# Altium schematic sheet UVLO_MODULE_TOP.SchDoc(TOP)
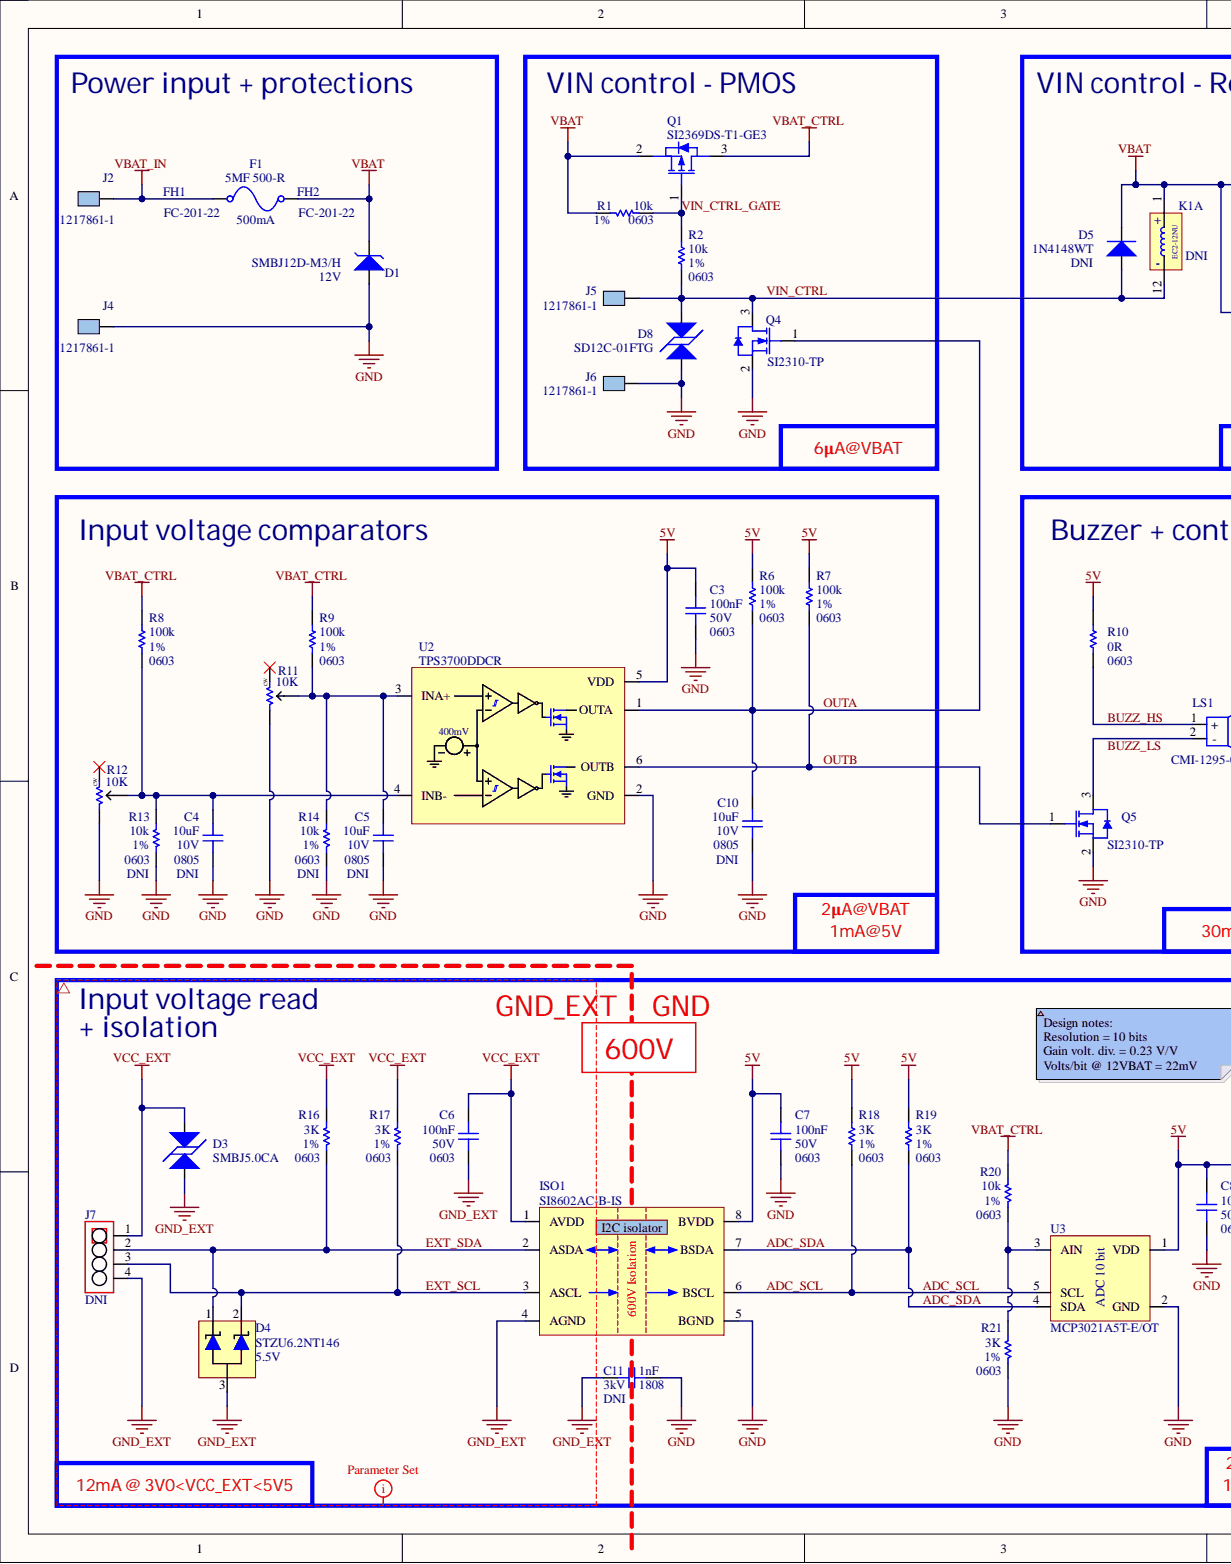
[diagram p0: UVLO_MODULE_TOP.SchDoc, left]
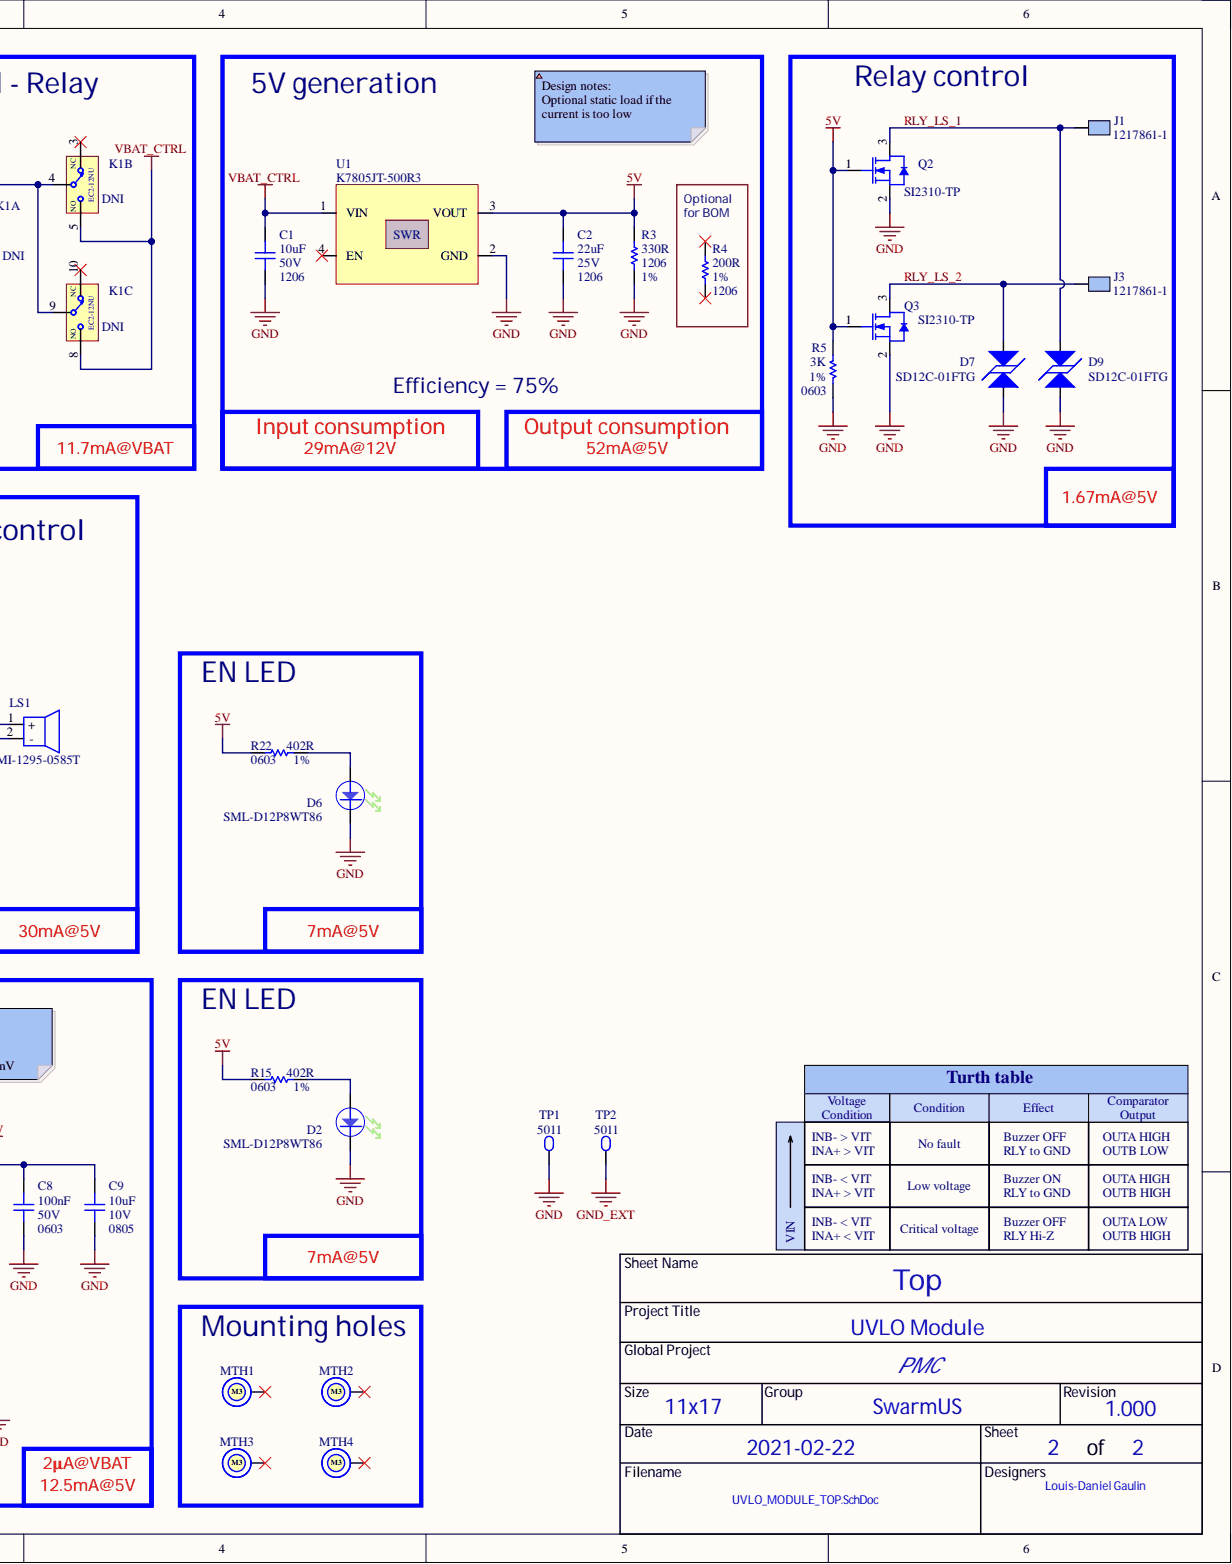
[diagram p1: UVLO_MODULE_TOP.SchDoc, right]

## Parts
C1  value 10uF  Package: 1206  Voltage Rating: 50V  [CAP_CER_1206]  (hidden comment: GRT31CR61H106KE01L)
C2  value 22uF  Package: 1206  Voltage Rating: 25V  [CAP_CER_1206]  (hidden comment: CL31A226KAHNNNE)
C3  value 100nF  Package: 0603  Voltage Rating: 50V  [CAP_CER_0603]  (hidden comment: CC0603KRX7R9BB104)
C4  value 10uF  Assembly Note: DNI  Package: 0805  Voltage Rating: 10V  [CAP_CER_0805]  (hidden comment: LMK212BJ106KG-T)
C5  value 10uF  Assembly Note: DNI  Package: 0805  Voltage Rating: 10V  [CAP_CER_0805]  (hidden comment: LMK212BJ106KG-T)
C6  value 100nF  Package: 0603  Voltage Rating: 50V  [CAP_CER_0603]  (hidden comment: CC0603KRX7R9BB104)
C7  value 100nF  Package: 0603  Voltage Rating: 50V  [CAP_CER_0603]  (hidden comment: CC0603KRX7R9BB104)
C8  value 100nF  Package: 0603  Voltage Rating: 50V  [CAP_CER_0603]  (hidden comment: CC0603KRX7R9BB104)
C9  value 10uF  Package: 0805  Voltage Rating: 10V  [CAP_CER_0805]  (hidden comment: LMK212BJ106KG-T)
C10  value 10uF  Assembly Note: DNI  Package: 0805  Voltage Rating: 10V  [CAP_CER_0805]  (hidden comment: LMK212BJ106KG-T)
C11  value 1nF  Assembly Note: DNI  Package: 1808  Voltage Rating: 3kV  [CAP_CER_1808]  (hidden comment: 1808HC102KAT1A)
D1  SMBJ12D-M3/H  value 12V  [TVS_SMBJ12D-M3/H]
D2  SML-D12P8WT86  [LED_SML-D12P8WT86]
D3  SMBJ5.0CA  [TVS_PWR_SMBJ5.0CA]
D4  STZU6.2NT146  Voltage Rating: 5.5V  [TVS_DATA_STZU6.2NT146]
D5  1N4148WT  Assembly Note: DNI  [DIO_1N4148WT]
D6  SML-D12P8WT86  [LED_SML-D12P8WT86]
D7  SD12C-01FTG  [TVS_DATA_SD12C-01FTG]
D8  SD12C-01FTG  [TVS_DATA_SD12C-01FTG]
D9  SD12C-01FTG  [TVS_DATA_SD12C-01FTG]
F1  5MF 500-R  Current Rating: 500mA  [FUS_5MF 500-R]
FH1  FC-201-22  [FHO_FC-201-22]
FH2  FC-201-22  [FHO_FC-201-22]
ISO1  SI8602AC-B-IS  value 600V Isolation  [ISO_SI8602AC-B-IS]
J1  1217861-1  [CON_1217861-1]
J2  1217861-1  [CON_1217861-1]
J3  1217861-1  [CON_1217861-1]
J4  1217861-1  [CON_1217861-1]
J5  1217861-1  [CON_1217861-1]
J6  1217861-1  [CON_1217861-1]
J7  Assembly Note: DNI  [CON_HDR_1X4]  (hidden comment: CON_HDR_1X4)
K1  EC2-12NU  Assembly Note: DNI  [RLY_EC2-12NU]  (parts A,B,C)
LS1  CMI-1295-0585T  [UND_SPK_CMI-1295-0585T]
MTH1  M3  [MTH_M3]  (no BOM)
MTH2  M3  [MTH_M3]  (no BOM)
MTH3  M3  [MTH_M3]  (no BOM)
MTH4  M3  [MTH_M3]  (no BOM)
Q1  SI2369DS-T1-GE3  [TRX_PMOS_SI2369DS-T1-GE3]
Q2  SI2310-TP  [TRX_NMOS_SI2310-TP]
Q3  SI2310-TP  [TRX_NMOS_SI2310-TP]
Q4  SI2310-TP  [TRX_NMOS_SI2310-TP]
Q5  SI2310-TP  [TRX_NMOS_SI2310-TP]
R1  value 10k  Package: 0603  Tolerance: 1%  [RES_0603]  (hidden comment: RC0603FR-0710KL)
R2  value 10k  Package: 0603  Tolerance: 1%  [RES_0603]  (hidden comment: RC0603FR-0710KL)
R3  value 330R  Package: 1206  Tolerance: 1%  [RES_1206]  (hidden comment: RC1206FR-07330RL)
R4  value 200R  Package: 1206  Tolerance: 1%  [RES_1206]  (hidden comment: CRCW1206200RFKEAC)  (mechanical)
R5  value 3K  Package: 0603  Tolerance: 1%  [RES_0603]  (hidden comment: RC0603FR-073KL)
R6  value 100k  Package: 0603  Tolerance: 1%  [RES_0603]  (hidden comment: RC0603FR-07100KL)
R7  value 100k  Package: 0603  Tolerance: 1%  [RES_0603]  (hidden comment: RC0603FR-07100KL)
R8  value 100k  Package: 0603  Tolerance: 1%  [RES_0603]  (hidden comment: RC0603FR-07100KL)
R9  value 100k  Package: 0603  Tolerance: 1%  [RES_0603]  (hidden comment: RC0603FR-07100KL)
R10  value 0R  Package: 0603  [RES_0603]  (hidden comment: RC0603JR-070RL)
R11  value 10K  [POT_3362P-1-103LF]  (hidden comment: 3362P-1-103LF)
R12  value 10K  [POT_3362P-1-103LF]  (hidden comment: 3362P-1-103LF)
R13  value 10k  Assembly Note: DNI  Package: 0603  Tolerance: 1%  [RES_0603]  (hidden comment: RC0603FR-0710KL)
R14  value 10k  Assembly Note: DNI  Package: 0603  Tolerance: 1%  [RES_0603]  (hidden comment: RC0603FR-0710KL)
R15  value 402R  Package: 0603  Tolerance: 1%  [RES_0603]  (hidden comment: RC0603FR-07402RL)
R16  value 3K  Package: 0603  Tolerance: 1%  [RES_0603]  (hidden comment: RC0603FR-073KL)
R17  value 3K  Package: 0603  Tolerance: 1%  [RES_0603]  (hidden comment: RC0603FR-073KL)
R18  value 3K  Package: 0603  Tolerance: 1%  [RES_0603]  (hidden comment: RC0603FR-073KL)
R19  value 3K  Package: 0603  Tolerance: 1%  [RES_0603]  (hidden comment: RC0603FR-073KL)
R20  value 10k  Package: 0603  Tolerance: 1%  [RES_0603]  (hidden comment: RC0603FR-0710KL)
R21  value 3K  Package: 0603  Tolerance: 1%  [RES_0603]  (hidden comment: RC0603FR-073KL)
R22  value 402R  Package: 0603  Tolerance: 1%  [RES_0603]  (hidden comment: RC0603FR-07402RL)
TP1  5011  [TPO_5011]
TP2  5011  [TPO_5011]
U1  K7805JT-500R3  [REG_SWR_K7805JT-500R3]
U2  TPS3700DDCR  [CMP_TPS3700DDCR]
U3  MCP3021A5T-E/OT  [ADC_MCP3021A5T-E/OT]

## Nets
5V: C2.2 C3.2 C7.2 C8.2 C9.2 ISO1.8(BVDD) Q2.1 Q3.1 R3.2 R5.2 R6.2 R7.2 R10.2 R15.1 R18.2 R19.2 R22.1 U1.3(VOUT) U2.5(VDD) U3.1(VDD)
GND: C1.1 C2.1 C3.1 C4.1 C5.1 C7.1 C8.1 C9.1 C10.1 C11.1 D1.1 D2.K D6.K D7.2 D8.2 D9.2 ISO1.5(BGND) J4.1 J6.1 Q2.2 Q3.2 Q4.2 Q5.2 R3.1 R5.1 R11.1 R12.1 R13.1 R14.1 R21.1 TP1.1 U1.2(GND) U2.2(GND) U3.2(GND)
GND_EXT: C6.1 C11.2 D3.2 D4.3 ISO1.4(AGND) J7.4 TP2.1
VBAT: D1.2 D5.K FH2.1 K1.1 K1.4 K1.9 Q1.2 R1.2
VBAT_CTRL: C1.2 K1.5 K1.8 Q1.3 R8.2 R9.2 R20.2 U1.1(VIN)
VBAT_IN: FH1.1 J2.1
VCC_EXT: C6.2 D3.1 ISO1.1(AVDD) J7.1 R16.2 R17.2
ADC_SCL: ISO1.6(BSCL) R18.1 U3.5(SCL)
ADC_SDA: ISO1.7(BSDA) R19.1 U3.4(SDA)
BUZZ_HS: LS1.1 R10.1
BUZZ_LS: LS1.2 Q5.3
EXT_SCL: D4.2 ISO1.3(ASCL) J7.3 R17.1
EXT_SDA: D4.1 ISO1.2(ASDA) J7.2 R16.1
OUTA: C10.2 Q4.1 R6.1 U2.1(OUTA)
OUTB: Q5.1 R7.1 U2.6(OUTB)
RLY_LS_1: D9.1 J1.1 Q2.3
RLY_LS_2: D7.1 J3.1 Q3.3
VIN_CTRL: D5.A D8.1 J5.1 K1.12 Q4.3 R2.1
VIN_CTRL_GATE: Q1.1 R1.1 R2.2
NetC4_2: C4.2 R8.1 R12.2 R13.2 U2.4(INB-)
NetC5_2: C5.2 R9.1 R11.2 R14.2 U2.3(INA+)
NetD2_A: D2.A R15.2
NetD6_A: D6.A R22.2
NetR20_1: R20.1 R21.2 U3.3(AIN)

Not connected: K1 3 10; MTH1 1; MTH2 1; MTH3 1; MTH4 1; R4 1 2; R11 3; R12 3; U1 4(EN)

## Library data of the parts
C1 = CAP_CER_GRT31CR61H106KE01L: CAP - CER 10UF 10% 50V X5R - 1206; Manufacturer #1: Murata Electronics North America; Cryo: UNKNOWN; GRAMS Lib Spec: 2.8; Polarized: No; Assembly Type: SMD; Component Kind: Standard; HelpURL: https://search.murata.co.jp/Ceramy/image/img/A01X/G101/ENG/GRT31CR61H106KE01-01.pdf; Library Name: GRAMS_MASTER_LIB.IntLib; Magnetic Material: Unknown; Part Number #1: GRT31CR61H106KE01L; Supplier 1: Digi-Key; Supplier Part Number 1: 490-12456-1-ND; Temperature: -55°C ~ 85°C; Tolerance: 10%; CreatedBy/Date: Nicolas Roy - 2018/12/19; VerifiedBy: Nicolas V. (2019-01-22)
C2 = CAP_CER_CL31A226KAHNNNE: CAP - CER 22uF 10% 25V X5R - 1206; Manufacturer #1: Samsung Electro-Mechanics; Cryo: UNKNOWN; GRAMS Lib Spec: 2.8; Polarized: NO; Assembly Type: SMD; Component Kind: Standard; HelpURL: http://www.samsungsem.com/global/support/product-search/mlcc/1207445_4880.html; Library Name: GRAMS_MASTER_LIB.IntLib; Magnetic Material: Unknown; Part Number #1: CL31A226KAHNNNE; Part Number #2: 1276-3047-1-ND; Supplier 1: Digi-Key; Supplier Part Number 1: 1276-3047-1-ND; Temperature: -55°C ~ 85°C; Tolerance: 10%; CreatedBy/Date: Philippe Arsenault/21 Janvier 2020; VerifiedBy: C.Paulin - 28/01/2020
C3 C6-C8 = CAP_CER_CC0603KRX7R9BB104: CAP - CER 100nF 50V X7R - 0603; Manufacturer #1: Yageo; Cryo: UNKNOWN; GRAMS Lib Spec: 2.8; Polarized: NO; Tolerance: 10%; Assembly Type: SMD; Component Kind: Standard; HelpURL: https://www.yageo.com/upload/media/product/productsearch/datasheet/mlcc/UPY-GPHC_X7R_6.3V-to-50V_18.pdf; Magnetic Material: UNKNOWN; Part Number #1: CC0603KRX7R9BB104; Supplier 1: Digi-Key; Supplier Part Number 1: 311-1344-1-ND; Temperature: -55°C ~ 125°C; CreatedBy/Date: Louis-Daniel Gaulin / 25-02-2021; Library Name: SWARMUS_LIB.IntLib; VerifiedBy: Hubert Dube - 26/02/2021
C4 C5 C9 C10 = CAP_CER_LMK212BJ106KG-T: CAP - CER 10UF 10% 10V X5R - 0805; Manufacturer #1: Taiyo Yuden; Cryo: UNKNOWN; GRAMS Lib Spec: 2.8; Polarized: NO; Assembly Type: SMD; Component Kind: Standard; HelpURL: https://www.yuden.co.jp/productdata/catalog/mlcc_all_e.pdf; Library Name: GRAMS_MASTER_LIB.IntLib; Magnetic Material: Unknown; Part Number #1: LMK212BJ106KG-T; Supplier 1: Digi-Key; Supplier Part Number 1: 587-1300-1-ND; Temperature: -55°C ~ 85°C; Tolerance: 10%; CreatedBy/Date: Caroline Paulin / 25 juillet 2018; VerifiedBy: Nicolas V. (2019-01-22)
C11 = CAP_CER_1808HC102KAT1A: CAP - CER 1nF 10% 3kV X7R - 1808; Manufacturer #1: AVX Corporation; Cryo: UNKNOWN; GRAMS Lib Spec: 2.8; Polarized: No; Assembly Type: SMD; Component Kind: Standard; HelpURL: http://datasheets.avx.com/AVX-HV_MLCC.pdf; Library Name: GRAMS_MASTER_LIB.IntLib; Magnetic Material: Unknown; Part Number #1: 1808HC102KAT1A; Supplier 1: Digi-Key; Supplier Part Number 1: 478-2981-1-ND; Temperature: -55°C ~ 125°C; Tolerance: 10%; CreatedBy/Date: Joel Lemay 2018-08-29; VerifiedBy: Caroline Paulin - 7 sept 2018
D1 = TVS_SMBJ12D-M3/H: TVS - DIODE 12V 19.6V - DO-214AA; Manufacturer #1: Vishay Semiconductor Diodes Division; Cryo: UNKNOWN; Current Rating: 30.6A peak pulse; GRAMS Lib Spec: 2.8c; Polarized: Yes; Power Max: 600W peak pulse; Voltage Rating: Vbr = 13.5, Vc = 19.6; Assembly Type: SMD; Component Kind: Standard; HelpURL: http://www.vishay.com/docs/87606/87606.pdf; Library Name: GRAMS_MASTER_LIB.IntLib; Magnetic Material: Unknown; Package: DO-214AA; Part Number #1: SMBJ12D-M3/H; Supplier 1: Digi-Key; Supplier Part Number 1: SMBJ12D-M3/HGICT-ND; Temperature: -55°C ~ 150°C; CreatedBy/Date: Joel Lemay 2019-04-17; VerifiedBy: C.Paulin 6/02/2020
D2 D6 = LED_SML-D12P8WT86: LED - GREEN DIFFUSED 0603 SMD - 0603; Manufacturer #1: Rohm Semiconductor; Cryo: UNKNOWN; Current Rating: 1-20mA; GRAMS Lib Spec: 2.8; Note: Operate at 2mA; Polarized: Yes; Value: 560 nm (green); Voltage rating: 2.2V (Forward), 5V (max Reverse); Assembly Type: SMD; Component Kind: Standard; HelpURL: https://d1d2qsbl8m0m72.cloudfront.net/en/products/databook/datasheet/opto/led/chip_mono/sml-d12x8_d13x8-e.pdf; Library Name: GRAMS_MASTER_LIB.IntLib; Magnetic Material: Unknown; Package: 0603; Part Number #1: SML-D12P8WT86; Supplier 1: Digi-Key; Supplier Part Number 1: 511-1579-2-ND; Temperature: -40 ~ 85 °C; CreatedBy/Date: Keven Deslandes - 2018/11/21; VerifiedBy: Caroline Paulin - 13 février 2019
D3 = TVS_PWR_SMBJ5.0CA: TVS - BIDIR 5V 9.2V 600W - DO214AA; Manufacturer #1: Littelfuse Inc.; Cryo: UNKNOWN; Current Rating: 65.3 A (Ipp); GRAMS Lib Spec: 2.8; Polarized: NO; Power Max: 600W (Pppm); Value: Vbr = 6.4V -  Clamp = 9.2V; Voltage Rating: 5V Standoff; Assembly Type: SMD; Component Kind: Standard; HelpURL: http://www.littelfuse.com/~/media/electronics/datasheets/tvs_diodes/littelfuse_tvs_diode_smbj_datasheet.pdf.pdf; Library Name: GRAMS_MASTER_LIB.IntLib; Magnetic Material: UNKNOWN; Package: DO214AA; Part Number #1: SMBJ5.0CA; Supplier 1: Digi-Key; Supplier Part Number 1: SMBJ5.0CALFCT-ND; Temperature: -65°C ~ 150°C (TJ); CreatedBy/Date: Jonathan Bouchard - 2019-03-22; VerifiedBy: Nicolas V. (2019-05-06)
D4 = TVS_DATA_STZU6.2NT146: TVS - TVS DIODE 5.5V 5.9V - SOT-23-3; Manufacturer #1: Rohm Semiconductor; Cryo: UNKNOWN; GRAMS Lib Spec: 2.8; Polarized: No; Power Max: 0.2W; Assembly Type: SMD; Component Kind: Standard; HelpURL: https://d1d2qsbl8m0m72.cloudfront.net/en/products/databook/datasheet/discrete/diode/zener/stzu6.2nt146-e.pdf; Magnetic Material: Unknown; Package: SOT-23-3; Part Number #1: STZU6.2NT146; Supplier 1: Digi-Key; Supplier Part Number 1: 846-STZU6.2NT146CT-ND; Temperature: 150°C (TJ)/ -55 to 150°C (ST); CreatedBy/Date: Louis-Daniel Gaulin / 02-03-2021; Library Name: SWARMUS_LIB.IntLib; VerifiedBy: Philippe Arsenault / 02-03-2021
D5 = DIO_1N4148WT: DIO - 1N4148WT - SOD-523F; Manufacturer #1: On Semiconductor; Cryo: UNKNOWN; Current Rating: 200mA; GRAMS Lib Spec: 2.8; Polarized: Yes; Power Max: 200mW; Value: 1V @ 10mA; Voltage Rating: 75V; Assembly Type: SMD; Component Kind: Standard; HelpURL: https://www.fairchildsemi.com/datasheets/1N/1N914BWT.pdf; Library Name: GRAMS_MASTER_LIB.IntLib; Magnetic Material: UNKNOWN; Package: SOD-523F; Part Number #1: 1N4148WT; Supplier 1: Digi-Key; Supplier Part Number 1: 1N4148WTCT-ND; Temperature: -55°C ~ 150°C; CreatedBy/Date: Joel Lemay 2018-06-15; VerifiedBy: Arnaud Samson 17-07-18
D7-D9 = TVS_DATA_SD12C-01FTG: TVS - BIDIR 12V 450W - SOD-323; Manufacturer #1: Littelfuse Inc.; Cryo: UNKNOWN; Current Rating: 17.0 A (Ipp); GRAMS Lib Spec: 2.9; Polarized: NO; Power Max: 450W (Ppk); Value: Vbr = 13.3V -  Clamp = 18.5V; Voltage Rating: 12V Standoff; Assembly Type: SMD; Component Kind: Standard; HelpURL: https://www.littelfuse.com/~/media/electronics/datasheets/tvs_diode_arrays/littelfuse_tvs_diode_array_sd_c_datasheet.pdf.pdf; Library Name: GRAMS_MASTER_LIB.IntLib; Magnetic Material: UNKNOWN; Package: SOD-323; Part Number #1: SD12C-01FTG; Supplier 1: Digi-Key; Supplier Part Number 1: F6442CT-ND; Temperature: -40°C ~ 125°C (TJ); CreatedBy/Date: O.D.Frenette - 2020-09-01; VerifiedBy: C.Paulin - 2020-09-09
F1 = FUS_5MF 500-R: FUS - CARTRIDGE GLASS 500mA 250VAC/125VDC - 5X20mm; Manufacturer #1: Bel Fuse Inc.; Cryo: UNKNOWN; GRAMS Lib Spec: 2.8c; Note: Use with 2x FC-201-22 clip; Polarized: NO; Rule: Component Clearance Constraint [Horizontal Clearance = 0mil    Vertical Clearance = 0mil]; Value: 500mA, Fast blow; Assembly Type: MANUAL; Component Kind: Standard; HelpURL: https://belfuse.com/resources/datasheets/circuitprotection/ds-cp-5mf-5mfp-series.pdf; Magnetic Material: UNKNOWN; Package: 3AB; Part Number #1: 5MF 500-R; Supplier 1: Digi-Key; Supplier Part Number 1: 507-1259-ND; Temperature: -55°C ~ 125°C; Voltage Rating: 250VAC/125VDC; CreatedBy/Date: Louis-Daniel Gaulin; Library Name: SWARMUS_LIB.IntLib; VerifiedBy: Hubert Dube - 26/02/2021
FH1 FH2 = FHO_FC-201-22: FHO - FUSE CLIP 5mm CARTRIDGE 10A PCB - 2-TH; Manufacturer #1: Bel Fuse Inc.; Cryo: UNKNOWN; GRAMS Lib Spec: 2.8; Polarized: Yes; Value: for 5mm diameter fuses; Assembly Type: TH; Component Kind: Standard; Current Rating: 10A; HelpURL: https://belfuse.com/resources/datasheets/circuitprotection/ds-cp-0672-fuse-clips-series.pdf; Magnetic Material: UNKNOWN; Package: 2-TH; Part Number #1: FC-201-22; Supplier 1: Digi-Key; Supplier Part Number 1: FC-201-22-ND; CreatedBy/Date: Louis-Daniel Gaulin / 26-02-2021; Library Name: SWARMUS_LIB.IntLib; VerifiedBy: Hubert Dube - 26/02/2021
ISO1 = ISO_SI8602AC-B-IS: ISO - DGTL ISO 600V 2CH I2C  - 8-SOIC; Manufacturer #1: Silicon Labs; Cryo: UNKNOWN; GRAMS Lib Spec: 2.8; Polarized: YES; Voltage Rating: 3 V ~ 5.5 V; Assembly Type: SMD; Component Kind: Standard; HelpURL: https://www.silabs.com/documents/public/data-sheets/Si860x.pdf; Magnetic Material: UNKNOWN; Package: 8-SOIC; Part Number #1: SI8602AC-B-IS; Supplier 1: Digi-Key; Supplier Part Number 1: 336-2050-5-ND; Temperature: -40°C ~ 125°C; CreatedBy/Date: Louis-Daniel Gaulin / 25-02-2021; Library Name: SWARMUS_LIB.IntLib; VerifiedBy: Hubert Dube - 26/02/2021
J1-J6 = CON_1217861-1: CON - Quick connect tab - TH; Manufacturer #1: TE Connectivity AMP Connectors; Cryo: UNKNOWN; GRAMS Lib Spec: 2.8; Polarized: Yes; Value: 250 mills; Assembly Type: TH; Component Kind: Standard; Current Rating: -; HelpURL: https://www.te.com/commerce/DocumentDelivery/DDEController?Action=srchrtrv&DocNm=1217861&DocType=Customer+Drawing&DocLang=English; Magnetic Material: Unknown; Manufacturer #2: -; Package: TH; Part Number #1: 1217861-1; Part Number #2: -; Power Max: -; Supplier 1: Digi-Key; Supplier Part Number 1: A100452CT-ND; Temperature: -; Tolerance: -; CreatedBy/Date: Louis-Daniel Gaulin / 24-02-2021; Library Name: SWARMUS_LIB.IntLib; VerifiedBy: Hubert Dube - 26/02/2021
J7 = CON_HDR_1X4: CON - Header 1X4 pins, 100Mils - TH-4; Cryo: UNKNOWN; GRAMS Lib Spec: 2.8; Polarized: No; Assembly Type: TH; Component Kind: Standard; Library Name: GRAMS_MASTER_LIB.IntLib; Magnetic Material: UNKNOWN; Package: TH-4; CreatedBy/Date: Nicolas V (2020-02-24); VerifiedBy: Nicolas V (2020-02-24)
K1 = RLY_EC2-12NU: RLY - GENERAL PURPOSE DPDT 2A 12V - TH-8; Manufacturer #1: KEMET; Cryo: UNKNOWN; GRAMS Lib Spec: 2.8; Magnetic Material: YES; Value: 5V Coil; Voltage Rating: 250VAC, 220VDC - Max; Assembly Type: TH; Component Kind: Standard; Current Rating: 2A; HelpURL: https://content.kemet.com/datasheets/KEM_R7002_EC2_EE2.pdf; Package: 8-TH; Part Number #1: EC2-12NU; Polarized: YES; Supplier 1: Digi-Key; Supplier Part Number 1: 399-11029-5-ND; Temperature: -40°C ~ 85°C; CreatedBy/Date: Louis-Daniel Gaulin/02-03-2021; Library Name: SWARMUS_LIB.IntLib; VerifiedBy: Philippe Arsenault/02-03-2021
LS1 = UND_LS_CMI-1295-0585T: LS - Buzzer indicator 5V 30mA 2.3kHz 85dB - TH; Manufacturer #1: CUI Devices; Cryo: UNKNOWN; GRAMS Lib Spec: 2.8; Polarized: Yes; Value: 85dB @ 5V, 10cm; Voltage Rating: 4 ~ 7V; Assembly Type: TH; Component Kind: Standard; Current Rating: 30 mA; HelpURL: https://www.cuidevices.com/product/resource/cmi-1295-0585t.pdf; Magnetic Material: Unknown; Manufacturer #2: -; Package: TH; Part Number #1: CMI-1295-0585T; Part Number #2: -; Power Max: -; Supplier 1: Digi-Key; Supplier Part Number 1: 102-CMI-1295-0585T-ND; Temperature: -40°C ~ 85°C; Tolerance: -; CreatedBy/Date: Louis-Daniel Gaulin / 24-02-2021; Library Name: SWARMUS_LIB.IntLib; VerifiedBy: Hubert Dube - 26/02/2021
MTH1-MTH4 = MTH_M3: MTH - MOUNTING HOLE M3 PLATED - M3; Cryo: UNKNOWN; GRAMS Lib Spec: 2.8; Magnetic Material: NO; Assembly Type: NONE; HelpURL: https://littlemachineshop.com/images/gallery/PDF/TapDrillSizes.pdf; Library Name: GRAMS_MASTER_LIB.IntLib; Package: M3; CreatedBy/Date: Jonathan Bouchard 2018-07-23; VerifiedBy: Arnaud Samson 23-07-18
Q1 = TRX_PMOS_SI2369DS-T1-GE3: TRX - PMOS 30V 7.6A - SOT−23; Manufacturer #1: Vishay Siliconix; Cryo: UNKNOWN; GRAMS Lib Spec: 2.8; Polarized: Yes; Power Max: 1.25W; Assembly Type: SMD; Component Kind: Standard; Current Rating: 7.6A; HelpURL: https://www.vishay.com/docs/62865/si2369d.pdf; Magnetic Material: Unknown; Package: SOT-23; Part Number #1: SI2369DS-T1-GE3; Supplier 1: Digi-Key; Supplier Part Number 1: SI2369DS-T1-GE3CT-ND; Temperature: -55°C ~ 150°C; Voltage Rating: 30V; CreatedBy/Date: Louis-Daniel Gaulin / 30-07-2020; Library Name: SWARMUS_LIB.IntLib; VerifiedBy: Philippe Arsenault/2020-11-06
Q2-Q5 = TRX_NMOS_SI2310-TP: TRX - MOSFET N-CH 60V 3A - SOT-23; Manufacturer #1: Micro Commercial Co; Cryo: UNKNOWN; GRAMS Lib Spec: 2.8c; Polarized: Yes; Power Max: 350mW; Voltage rating: 60V (Vds max), 2V (Vgs @ 250uA); Assembly Type: SMD; Component Kind: Standard; Current Rating: 3A; HelpURL: https://www.mccsemi.com/pdf/Products/SI2310(SOT-23)-A.pdf; Library Name: GRAMS_MASTER_LIB.IntLib; Magnetic Material: UNKNOWN; Package: SOT-23; Part Number #1: SI2310-TP; Supplier 1: Digi-Key; Supplier Part Number 1: SI2310-TPMSCT-ND; Temperature: -55°C ~ 150°C (TJ); CreatedBy/Date: Louis-Daniel Gaulin / 20-02-2020; VerifiedBy: C.Paulin - 21/02/2020
R1 R2 R13 R14 R20 = RES_RC0603FR-0710KL: RES - 10k 1% 1/10W - 0603; Manufacturer #1: Yageo; GRAMS Lib Spec: 2.8; Magnetic Material: Unknown; Polarized: No; Voltage Rating: 75V; Assembly Type: SMD; Component Kind: Standard; HelpURL: https://www.yageo.com/upload/media/product/productsearch/datasheet/rchip/PYu-RC_Group_51_RoHS_L_11.pdf; Part Number #1: RC0603FR-0710KL; Power Max: 1/10W; Supplier 1: Digi-Key; Supplier Part Number 1: 311-10.0KHRCT-ND; Temperature: -55°C ~ 155°C; CreatedBy/Date: Louis-Daniel Gaulin / 24-02-2021; Library Name: SWARMUS_LIB.IntLib; VerifiedBy: Hubert Dube - 26/02/2021
R3 = RES_RC1206FR-07330RL: RES - 330 OHM 1% 1/4W - 1206; Manufacturer #1: Yageo; Cryo: UNKNOWN; GRAMS Lib Spec: 2.8; Polarized: No; Voltage Rating: 200V; Assembly Type: SMD; Component Kind: Standard; HelpURL: https://www.yageo.com/upload/media/product/productsearch/datasheet/rchip/PYu-RC_Group_51_RoHS_L_10.pdf; Library Name: GRAMS_MASTER_LIB.IntLib; Magnetic Material: Unknown; Part Number #1: RC1206FR-07330RL; Power Max: 1/4W; Supplier 1: Digi-Key; Supplier Part Number 1: 311-330FRCT-ND; Temperature: -55°C ~ 155°C; CreatedBy/Date: Nicolas V. (2019-11-19); VerifiedBy: Nicolas V. (2019-11-19)
R4 = RES_CRCW1206200RFKEAC: RES - 200R 1% 1/4W - 1206; Manufacturer #1: Vishay Dale; GRAMS Lib Spec: 2.8; Magnetic Material: Unknown; Polarized: No; Voltage Rating: 75V; Assembly Type: SMD; HelpURL: https://www.vishay.com/docs/28773/crcwce3.pdf; Part Number #1: CRCW1206200RFKEAC; Power Max: 1/4W; Supplier 1: Digi-Key; Supplier Part Number 1: 541-4176-1-ND; Temperature: -55°C ~ 155°C; CreatedBy/Date: Louis-Daniel Gaulin / 26-02-2021; Library Name: SWARMUS_LIB.IntLib; VerifiedBy: Hubert Dubé/ 26-02-2021
R5 R16-R19 R21 = RES_RC0603FR-073KL: RES - 3k 1% 1/10W - 0603; Manufacturer #1: Yageo; GRAMS Lib Spec: 2.8; Magnetic Material: Unknown; Polarized: No; Voltage Rating: 75V; Assembly Type: SMD; Component Kind: Standard; HelpURL: https://www.yageo.com/upload/media/product/productsearch/datasheet/rchip/PYu-RC_Group_51_RoHS_L_11.pdf; Part Number #1: RC0603FR-073KL; Power Max: 1/10W; Supplier 1: Digi-Key; Supplier Part Number 1: 311-3.00KHRCT-ND; Temperature: -55°C ~ 155°C; CreatedBy/Date: Louis-Daniel Gaulin / 24-02-2021; Library Name: SWARMUS_LIB.IntLib; VerifiedBy: Hubert Dube - 26/02/2021
R6-R9 = RES_RC0603FR-07100KL: RES - 100k 1% 1/10W - 0603; Manufacturer #1: Yageo; GRAMS Lib Spec: 2.8; Magnetic Material: Unknown; Polarized: No; Voltage Rating: 75V; Assembly Type: SMD; Component Kind: Standard; HelpURL: https://www.yageo.com/upload/media/product/productsearch/datasheet/rchip/PYu-RC_Group_51_RoHS_L_11.pdf; Part Number #1: RC0603FR-07100KL; Power Max: 1/10W; Supplier 1: Digi-Key; Supplier Part Number 1: 311-100KHRCT-ND; Temperature: -55°C ~ 155°C; CreatedBy/Date: Louis-Daniel Gaulin / 24-02-2021; Library Name: SWARMUS_LIB.IntLib; VerifiedBy: Hubert Dube
R10 = RES_RC0603JR-070RL: RES - 0 ohm jumper 1/10W - 0603; Manufacturer #1: Yageo; Cryo: UNKNOWN; GRAMS Lib Spec: 2.8; Polarized: No; Assembly Type: SMD; Component Kind: Standard; HelpURL: http://www.yageo.com/documents/recent/PYu-RC_Group_51_RoHS_L_9.pdf; Library Name: GRAMS_MASTER_LIB.IntLib; Magnetic Material: Unknown; Part Number #1: RC0603JR-070RL; Power Max: 1/10W; Supplier 1: Digi-Key; Supplier Part Number 1: 311-0.0GRCT-ND; Temperature: -55°C ~ 155°C; Voltage Rating: -; CreatedBy/Date: L-P Gauthier 2018-10-30; VerifiedBy: Caroline Paulin  - 5/11/2018
R11 R12 = POT_3362P-1-103LF: RES -  POT 10K 1/2W LINEAR PLASTIC 1 turn - TH; Manufacturer #1: Bourns Inc.; Cryo: UNKNOWN; GRAMS Lib Spec: 2.8; Polarized: YES; Tolerance: 10%; Voltage Rating: 500V; Assembly Type: TH; Component Kind: Standard; HelpURL: https://www.bourns.com/docs/Product-Datasheets/3362.pdf; Magnetic Material: Unknown; Package: TH; Part Number #1: 3362P-1-103LF; Power Max: 1/2W; Supplier 1: Digi-Key; Supplier Part Number 1: 3362P-103LF-ND; CreatedBy/Date: Louis-Daniel Gaulin / 24-02-2021; Library Name: SWARMUS_LIB.IntLib; VerifiedBy: Hubert Dube - 26/02/2021
R15 R22 = RES_RC0603FR-07402RL: RES - 402R 1% 1/10W - 0603; Manufacturer #1: Yageo; GRAMS Lib Spec: 2.8; Magnetic Material: Unknown; Polarized: No; Voltage Rating: 75V; Assembly Type: SMD; Component Kind: Standard; HelpURL: https://www.yageo.com/upload/media/product/productsearch/datasheet/rchip/PYu-RC_Group_51_RoHS_L_11.pdf; Part Number #1: RC0603FR-07402RL; Power Max: 1/10W; Supplier 1: Digi-Key; Supplier Part Number 1: 311-402HRCT-ND; Temperature: -55°C ~ 155°C; CreatedBy/Date: Louis-Daniel Gaulin / 24-02-2021; Library Name: SWARMUS_LIB.IntLib; VerifiedBy: Hubert Dube - 26/02/2021
TP1 TP2 = TPO_5011: TPO - TEST POINT - TH; Manufacturer #1: Keystone Electronics; Cryo: UNKNOWN; GRAMS Lib Spec: 2.8; Polarized: No; Assembly Type: TH; Component Kind: Standard; HelpURL: https://www.keyelco.com/userAssets/file/M65p56.pdf; Magnetic Material: UNKNOWN; Part Number #1: 5011; Supplier 1: Digi-Key; Supplier Part Number 1: 36-5011-ND; CreatedBy/Date: Louis-Daniel Gauli / 02-11-2020; Library Name: SWARMUS_LIB.IntLib; VerifiedBy: Philippe Arsenault/2020-11-06
U1 = REG_SWR_K7805JT-500R3: REG_DC DC CONVERTER 5V 2.5W - 4-SMD Module; Manufacturer #1: Mornsun America, LLC; Cryo: UNKNOWN; Current Rating: 0.5A; GRAMS Lib Spec: 2.8; Polarized: Yes; Voltage Rating: 6.5V to 36V IN; Assembly Type: SMD; Component Kind: Standard; HelpURL: https://www.mornsun-power.com/html/pdf/K7805JT-500R3.html; Magnetic Material: Unknown; Package: 4-SMD Module; Part Number #1: K7805JT-500R3; Supplier 1: Digi-Key; Supplier Part Number 1: 2725-K7805JT-500R3CT-ND; Temperature: -40°C ~ 85°C; Value: 5V; CreatedBy/Date: Louis-Daniel Gaulin / 25-02-2021; Library Name: SWARMUS_LIB.IntLib; VerifiedBy: Hubert Dube - 26/02/2021
U2 = CMP_TPS3700DDCR: CMP - Comparator Window Open Drain - TSOT-23-6; Manufacturer #1: Texas Instruments; Current Rating: 40mA; GRAMS Lib Spec: 2.8; Polarized: YES; Voltage Rating: 1.8V ~ 18V; Assembly Type: SMT; Component Kind: Standard; HelpURL: https://www.ti.com/lit/ds/symlink/tps3700.pdf?ts=1613994469122&ref_url=https%253A%252F%252Fwww.ti.com%252Fproduct%252FTPS3700%253Futm_source%253Dgoogle%2526utm_medium%253Dcpc%2526utm_campaign%253Dapp-null-null-GPN_EN-cpc-pf-google-wwe%2526utm_content%253DTPS3700%2526ds_k%253DTPS3700%2526DCM%253Dyes%2526gclid%253DEAIaIQobChMI6PCR77X97gIVzUeRBR1hwwWTEAAYASAAEgJJbfD_BwE%2526gclsrc%253Daw.ds; Package: TSOT-23-6; Part Number #1: TPS3700DDCR; Supplier 1: Digi-Key; Supplier Part Number 1: 296-30395-2-ND; Temperature: -40°C ~ 125°C; CreatedBy/Date: Louis-Daniel Gaulin / 22-02-2021; Library Name: SWARMUS_LIB.IntLib; VerifiedBy: Hubert Dube - 26/02/2021
U3 = ADC_MCP3021A5T-E/OT: ADC - IC ADC 10BIT I2C 22.3KSPS - SOT-23-5; Manufacturer #1: Microchip Technology; Cryo: UNKNOWN; GRAMS Lib Spec: 2.8; Note: Slave I2C Adress = 1001101; Polarized: Yes; Value: 10 bit, 1 Channels, 22.3ksps; Voltage rating: 2.7V ~ 5.5V; Assembly Type: SMD; Component Kind: Standard; HelpURL: https://ww1.microchip.com/downloads/en/DeviceDoc/20001805C.pdf; Magnetic Material: UNKNOWN; Package: SOT-23-5; Part Number #1: MCP3021A5T-E/OT; Supplier 1: Digi-Key; Supplier Part Number 1: MCP3021A5T-E/OTCT-ND; Temperature: -40°C~125°C; CreatedBy/Date: Louis-Daniel Gaulin / 24-02-2021; Library Name: SWARMUS_LIB.IntLib; VerifiedBy: Hubert Dube - 26/02/2021
